# Altium schematic sheet MotorMCU.SchDoc
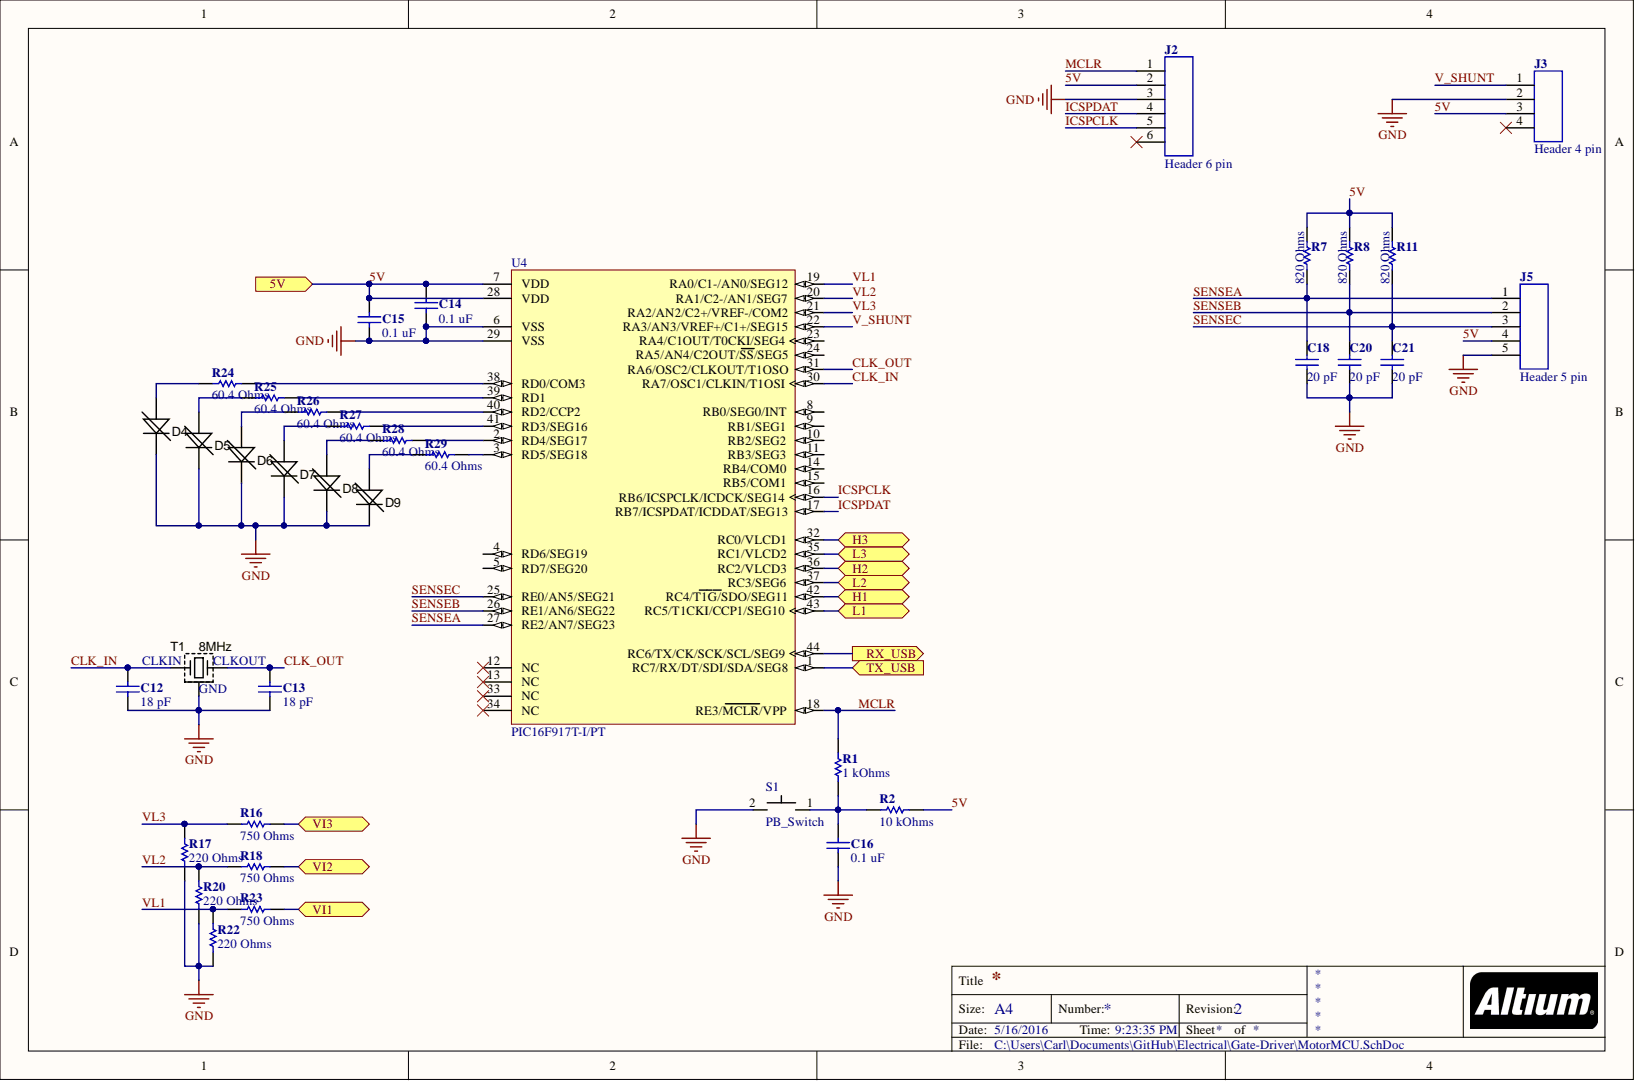
[diagram p0: MotorMCU.SchDoc]

## Parts
C12  18 pF  [CAP-0603(1608)-R]
C13  18 pF  [CAP-0603(1608)-R]
C14  0.1 uF  [CAP-0402(1005)-R]
C15  0.1 uF  [CAP-0402(1005)-R]
C16  0.1 uF  [CAP-0402(1005)-R]
C18  20 pF  [CAP-0402(1005)-R]
C20  20 pF  [CAP-0402(1005)-R]
C21  20 pF  [CAP-0402(1005)-R]
D4  Red  [LED-1206]  (value not drawn)
D5  Red  [LED-1206]  (value not drawn)
D6  Red  [LED-1206]  (value not drawn)
D7  Red  [LED-1206]  (value not drawn)
D8  Red  [LED-1206]  (value not drawn)
D9  Red  [LED-1206]  (value not drawn)
J2  Header 6 pin  [HEADER-6-100mil]
J3  Header 4 pin  [HEADER-4-100mil]
J5  Header 5 pin  [HEADER-5-100mil]
R1  1 kOhms  [R-0402(1005)-R]
R2  10 kOhms  [R-0603(1608)-R]
R7  820 Ohms  [R-0603(1608)-R]
R8  820 Ohms  [R-0603(1608)-R]
R11  820 Ohms  [R-0603(1608)-R]
R16  750 Ohms  [R-0603(1608)-R]
R17  220 Ohms  [R-0603(1608)-R]
R18  750 Ohms  [R-0603(1608)-R]
R20  220 Ohms  [R-0603(1608)-R]
R22  220 Ohms  [R-0603(1608)-R]
R23  750 Ohms  [R-0603(1608)-R]
R24  60.4 Ohms  [R-0603(1608)-R]
R25  60.4 Ohms  [R-0603(1608)-R]
R26  60.4 Ohms  [R-0603(1608)-R]
R27  60.4 Ohms  [R-0603(1608)-R]
R28  60.4 Ohms  [R-0603(1608)-R]
R29  60.4 Ohms  [R-0603(1608)-R]
S1  PB_Switch  [Pushbutton_switches]
T1  8MHz  Load Capacitance: 18 pF  [CRYSTAL-8MHZ]
U4  PIC16F917T-I/PT  [TQFP-44]

## Ports
5V, H1, H2, H3, L1, L2, L3, RX_USB, TX_USB, VI1, VI2, VI3

## Nets
GND: C12.2 C13.2 C14.2 C15.2 C16.1 C18.2 C20.2 C21.2 D4.K D5.K D6.K D7.K D8.K D9.K J2.3 J3.2 J5.5 R17.2 R20.2 R22.2 S1.2 T1.2 U4.6(VSS) U4.29(VSS)
5V: C14.1 C15.1 J2.2 J3.3 J5.4 R2.2 R7.1 R8.1 R11.1 U4.7(VDD) U4.28(VDD) ; port 5V
CLK_IN: C12.1 T1.1 U4.30(RA7/OSC1/CLKIN/T1OSI)
CLK_OUT: C13.1 T1.3 U4.31(RA6/OSC2/CLKOUT/T1OSO)
H1: U4.42(RC4/T1G/SDO/SEG11) ; port H1
H2: U4.36(RC2/VLCD3) ; port H2
H3: U4.32(RC0/VLCD1) ; port H3
ICSPCLK: J2.5 U4.16(RB6/ICSPCLK/ICDCK/SEG14)
ICSPDAT: J2.4 U4.17(RB7/ICSPDAT/ICDDAT/SEG13)
L1: U4.43(RC5/T1CKI/CCP1/SEG10) ; port L1
L2: U4.37(RC3/SEG6) ; port L2
L3: U4.35(RC1/VLCD2) ; port L3
MCLR: J2.1 R1.1 U4.18(RE3/MCLR/VPP)
RX_USB: U4.44(RC6/TX/CK/SCK/SCL/SEG9) ; port RX_USB
SENSEA: C18.1 J5.1 R7.2 U4.27(RE2/AN7/SEG23)
SENSEB: C20.1 J5.2 R8.2 U4.26(RE1/AN6/SEG22)
SENSEC: C21.1 J5.3 R11.2 U4.25(RE0/AN5/SEG21)
TX_USB: U4.1(RC7/RX/DT/SDI/SDA/SEG8) ; port TX_USB
V_SHUNT: J3.1 U4.22(RA3/AN3/VREF+/C1+/SEG15)
VI1: R23.2 ; port VI1
VI2: R18.2 ; port VI2
VI3: R16.2 ; port VI3
VL1: R22.1 R23.1 U4.19(RA0/C1-/AN0/SEG12)
VL2: R18.1 R20.1 U4.20(RA1/C2-/AN1/SEG7)
VL3: R16.1 R17.1 U4.21(RA2/AN2/C2+/VREF-/COM2)
NetC16_2: C16.2 R1.2 R2.1 S1.1
NetD4_A: D4.A R24.1
NetD5_A: D5.A R25.1
NetD6_A: D6.A R26.1
NetD7_A: D7.A R27.1
NetD8_A: D8.A R28.1
NetD9_A: D9.A R29.1
NetR24_2: R24.2 U4.38(RD0/COM3)
NetR25_2: R25.2 U4.39(RD1)
NetR26_2: R26.2 U4.40(RD2/CCP2)
NetR27_2: R27.2 U4.41(RD3/SEG16)
NetR28_2: R28.2 U4.2(RD4/SEG17)
NetR29_2: R29.2 U4.3(RD5/SEG18)

Not connected: J2 6; J3 4; U4 4(RD6/SEG19) 5(RD7/SEG20) 8(RB0/SEG0/INT) 9(RB1/SEG1) 10(RB2/SEG2) 11(RB3/SEG3) 12(NC) 13(NC) 14(RB4/COM0) 15(RB5/COM1) 23(RA4/C1OUT/T0CKI/SEG4) 24(RA5/AN4/C2OUT/SS/SEG5) 33(NC) 34(NC)

## Library data of the parts
C12 C13 = CAP- 18 pF- 25 V-CER-0603(1608): CAP CER 18 pF 10V 5% C0G 0603; Manufacturer Part Number: CC0603FRNPO9BN180; Brand: Yageo; Dielectric: C0G (NP0); Tolerance: 1 %; Type: Multilayer Ceramic Chip Capacitor; Voltage Rating DC: 50 VDC; Capacitance: 18 pF; Case Code - in: 0603; Case Code - mm: 1608; Category: Multilayer Ceramic Capacitors MLCC - SMD/SMT; Component Kind: Standard; ComponentLink1Description: http://www.mouser.com/ds/2/447/UPY-GP_NP0_16V-to-50V_8-3714.pdf; ComponentLink1URL: http://www.mouser.com/ds/2/447/UPY-GP_NP0_16V-to-50V_8-3714.pdf; Length: 1.6 mm; Manufacturer: Yageo; Maximum Operating Temperature: + 125 C; Minimum Operating Temperature: - 55 C; Minimum Order Quantity: 1; Package / Case: 0603 (1608 metric); Packaging: Reel; Pricing 1: 1=0.100, 10=0.039, 100=0.027, 1000=0.019, 4000=0.018, 8000=0.017, 24000=0.016, 48000=0.013 (USD); Product: General Type MLCCs; Reeling: True; RoHS: RoHS Compliant By Exemption; RoHS - Mouser: E; Stock 1: 2511; Supplier 1: Mouser; Supplier Part Number 1: 603-CC603FRNPO9BN180; Termination Style: SMD/SMT; Width: 0.8 mm; Library Name: CarlLibrary.SchLib
C14-C16 = CAP- 0.1 uF- 16 V-CER-0402(1005): CAP CER 0.1 UF 16V 5% X7R 0402; Manufacturer Part Number: CC0402KRX7R7BB104; Brand: Yageo; Tolerance: 10 %; Type: Multilayer Ceramic Chip Capacitor; Voltage Rating DC: 16 VDC; Capacitance: 0.1 uF; Case Code - in: 0402; Case Code - mm: 1005; Category: Multilayer Ceramic Capacitors MLCC - SMD/SMT; Component Kind: Standard; ComponentLink1Description: http://www.mouser.com/ds/2/447/UPY-GPHC_X7R_6.3V-to-50V_8-12304.pdf; ComponentLink1URL: http://www.mouser.com/ds/2/447/UPY-GPHC_X7R_6.3V-to-50V_8-12304.pdf; Dielectric: X7R; Manufacturer: Yageo; Maximum Operating Temperature: + 125 C; Minimum Operating Temperature: - 55 C; Minimum Order Quantity: 1; Package / Case: 0402 (1005 metric); Packaging: Reel; Pricing 1: 1=0.100, 10=0.009, 100=0.004, 1000=0.003, 2500=0.002, 10000=0.002 (USD); Product: General Type MLCCs; Reeling: True; RoHS: RoHS Compliant By Exemption; RoHS - Mouser: E; Standard Pack Qty: 10000; Stock 1: 655262; Supplier 1: Mouser; Supplier Part Number 1: 603-CC402KRX7R7BB104; Termination Style: SMD/SMT; Library Name: CarlLibrary.SchLib
C18 C20 C21 = CAP- 20 pF- 50 V-CER-0402(1005): CAP CER 20 pF 50V 5% C0G 0402; Manufacturer Part Number: CC0402JRNPO9BN200; Brand: Yageo; Dielectric: C0G (NP0); Type: Multilayer Ceramic Chip Capacitor; Voltage Rating DC: 50 VDC; Capacitance: 20 pF; Case Code - in: 0402; Case Code - mm: 1005; Category: Multilayer Ceramic Capacitors MLCC - SMD/SMT; Component Kind: Standard; ComponentLink1Description: http://www.mouser.com/ds/2/447/UPY-NP0X7R_MV_100-to-630V_15-594030.pdf; ComponentLink1URL: http://www.mouser.com/ds/2/447/UPY-NP0X7R_MV_100-to-630V_15-594030.pdf; Length: 1 mm; Manufacturer: Yageo; Maximum Operating Temperature: + 125 C; Minimum Operating Temperature: - 55 C; Minimum Order Quantity: 1; Package / Case: 0402 (1005 metric); Packaging: Reel; Pricing 1: 1=0.100, 10=0.010, 100=0.005, 1000=0.004, 2500=0.003, 10000=0.002 (USD); Product: General Type MLCCs; Reeling: True; RoHS: RoHS Compliant By Exemption; RoHS - Mouser: E; Standard Pack Qty: 10000; Stock 1: 75922; Supplier 1: Mouser; Supplier Part Number 1: 603-CC402JRNPO9BN200; Termination Style: SMD/SMT; Tolerance: 5 %; Width: 0.5 mm; Library Name: CarlLibrary.SchLib
D4-D9 = LED_SMD_RED_0805: LED, 0805 SMD, Red; Manufacturer Part Number: APTD3216SURCK; Brand: Kingbright; If - Forward Current: 20 mA; LED Size: 3.2 mm x 1.6 mm; Lens Color/Style: Water Clear; Luminous Intensity: 1000 mcd; Vf - Forward Voltage: 1.95 V; Viewing Angle: 50 deg; Wavelength/Color Temperature: 630 nm; Category: Standard LEDs - SMD; Component Kind: Standard; ComponentLink1Description: http://www.mouser.com/ds/2/216/APTD3216SURCK-65552.pdf; ComponentLink1URL: http://www.mouser.com/ds/2/216/APTD3216SURCK-65552.pdf; Illumination Color: Red; Manufacturer: Kingbright; Maximum Operating Temperature: + 85 C; Minimum Operating Temperature: - 40 C; Minimum Order Quantity: 1; Mounting Style: SMD/SMT; Package / Case: 1206; Packaging: Reel; Pricing 1: 1=0.270, 10=0.169, 100=0.125, 1000=0.103, 2000=0.092, 10000=0.084, 24000=0.075, 50000=0.071, 100000=0.068 (USD); Product Category: LED SMD Super Bright; Reeling: True; RoHS: RoHS Compliant; RoHS - Mouser: Y; Standard Pack Qty: 2000; Stock 1: 52291; Supplier 1: Mouser; Supplier Part Number 1: 604-APTD-3216SURCK; Library Name: CarlLibrary.SchLib
J2 = CON-6Pin-100Mil: Header, 6 pin, .1 pitch; Manufacturer Part Number: 4-103327-6; Brand: TE Connectivity / AMP; Current Rating: 3 A; Insulation Resistance: 5000 MOhms; Mating Post Length: 5.84 mm; Mounting Angle: Vertical; Number of Positions: 6 Position; Pitch: 2.54 mm; Category: Headers & Wire Housings; Component Kind: Standard; ComponentLink1Description: http://www.mouser.com/ds/2/418/NG_CD_103327_T_baseFilename-749374.pdf; ComponentLink1URL: http://www.mouser.com/ds/2/418/NG_CD_103327_T_baseFilename-749374.pdf; Contact Gender: Pin (Male); Contact Material: Phosphor Bronze; Contact Plating: Tin; Flammability Rating: UL 94 V-0; Housing Material: Thermoplastic; Manufacturer: TE Connectivity / AMP; Minimum Order Quantity: 1; Mounting Style: -; Number of Rows: 1 Row; Operating Temperature Range: - 65 C to + 105 C; Pricing 1: 1=0.230, 25=0.226, 50=0.212, 100=0.198 (USD); Product: Headers; Reeling: False; Row Spacing: 2.54 mm; Series: AMPMODU MOD II; Standard Pack Qty: 240; Stock 1: 9402; Supplier 1: Mouser; Supplier Part Number 1: 571-4-103327-6; Termination Post Length: 3.05 mm; Termination Style: Through Hole; Tradename: AMPMODU; Type: Breakaway; Library Name: CarlLibrary.SchLib
J3 = CON-4Pin-100Mil: Header, 4 pin, .1 pitch; Manufacturer Part Number: 90136-1104; Brand: Molex; Current Rating: 3 A; Mounting Angle: Vertical; Number of Positions: 4 Position; Pitch: 2.54 mm; Qualification: Commercial; Voltage Rating: 350 VAC/DC; Accessory Type: -; Category: Headers & Wire Housings; Component Kind: Standard; ComponentLink1Description: http://www.mouser.com/ds/2/276/0901361104_PCB_HEADERS-176953.pdf; ComponentLink1URL: http://www.mouser.com/ds/2/276/0901361104_PCB_HEADERS-176953.pdf; Contact Gender: Pin (Male); Contact Material: Brass; Contact Plating: Tin; Contact Type: -; Housing Material: Polyester; Manufacturer: Molex; Minimum Order Quantity: 1; Mounting Style: Straight Pin; Number of Rows: 1 Row; Packaging: Tray; Pricing 1: 1=1.17, 10=0.906, 100=0.717, 500=0.646, 1000=0.574, 2000=0.502 (USD); Product: Headers; Product Category: C-Grid Connectors; Reeling: False; RoHS: RoHS Compliant; RoHS - Mouser: Y; Row Spacing: -; Series: 90136; Standard Pack Qty: 1920; Stock 1: 2253; Supplier 1: Mouser; Supplier Part Number 1: 538-90136-1104; Termination Style: Through Hole; Tradename: C-Grid; Type: Shrouded; Library Name: CarlLibrary.SchLib
J5 = CON-5Pin-100Mil: Header, 5 pin, .1 pitch; Manufacturer Part Number: 90136-1105; Brand: Molex; Current Rating: 3 A; Mounting Angle: Vertical; Number of Positions: 5 Position; Pitch: 2.54 mm; Qualification: Commercial; Voltage Rating: 350 VAC/DC; Accessory Type: -; Category: Headers & Wire Housings; Component Kind: Standard; ComponentLink1Description: http://www.mouser.com/ds/2/276/0901361105_PCB_HEADERS-151780.pdf; ComponentLink1URL: http://www.mouser.com/ds/2/276/0901361105_PCB_HEADERS-151780.pdf; Contact Gender: Pin (Male); Contact Material: Brass; Contact Plating: Tin; Contact Type: -; Housing Material: Polyester; Manufacturer: Molex; Minimum Order Quantity: 1; Mounting Style: Through Hole; Number of Rows: 1 Row; Packaging: Tray; Pricing 1: 1=1.17, 10=0.934, 100=0.749, 500=0.675, 1000=0.600, 2000=0.544, 5000=0.525, 10000=0.461 (USD); Product: Headers; Product Category: C-Grid Connectors; Reeling: False; RoHS: RoHS Compliant; RoHS - Mouser: Y; Row Spacing: -; Series: 90136; Standard Pack Qty: 1600; Stock 1: 2202; Supplier 1: Mouser; Supplier Part Number 1: 538-90136-1105; Termination Style: Through Hole; Tradename: C-Grid; Type: Shrouded; Library Name: CarlLibrary.SchLib
R1 = R-1K-62.5 mW-0402(1005): RES 1K OHM 62.5 mW 0402(1005) SMD; Manufacturer Part Number: RC0402JR-071KL; Brand: Yageo; Power Rating: 62.5 mW (1/16 W); Temperature Coefficient: 100 PPM / C; Tolerance: 5 %; Voltage Rating: 50 V; Case Code - in: 0402; Case Code - mm: 1005; Category: Thick Film Resistors - SMD; Component Kind: Standard; ComponentLink1Description: http://www.mouser.com/ds/2/447/PYu-RC0402_51_RoHS_L_6_r-8721.pdf; ComponentLink1URL: http://www.mouser.com/ds/2/447/PYu-RC0402_51_RoHS_L_6_r-8721.pdf; Height: 0.35 mm; Length: 1 mm; Manufacturer: Yageo; Minimum Order Quantity: 1; Operating Temperature Range: - 55 C to + 155 C; Package / Case: 0402 (1005 metric); Packaging: Reel; Pricing 1: 1=0.100, 10=0.007, 100=0.002, 2500=0.001, 10000=0.001 (USD); Product: Thick Film Resistors General Purpose; Product Category: 0402 SMD Chip Resistors; Reeling: True; Resistance: 1 kOhms; RoHS: RoHS Compliant; RoHS - Mouser: Y; Series: RC; Standard Pack Qty: 10000; Stock 1: 20226; Supplier 1: Mouser; Supplier Part Number 1: 603-RC0402JR-071KL; Termination Style: SMD/SMT; Type: General Purpose Chip Resistor Surface Mount; Width: 0.5 mm; Library Name: CarlLibrary.SchLib
R2 = R-10k-100mW-0603: RES 10k OHM 1/10W 0603 SMD; Manufacturer Part Number: RC0603FR-0710KL; Brand: Yageo; Power Rating: 100 mW; Temperature Coefficient: 100 PPM / C; Tolerance: 1 %; Voltage Rating: 75 V; Case Code - in: 0603; Case Code - mm: 1608; Category: Thick Film Resistors - SMD; Component Kind: Standard; ComponentLink1Description: http://www.mouser.com/ds/2/447/RC0603-272842.pdf; ComponentLink1URL: http://www.mouser.com/ds/2/447/RC0603-272842.pdf; Height: 0.45 mm; Length: 1.6 mm; Manufacturer: Yageo; Minimum Order Quantity: 1; Operating Temperature Range: - 55 C to + 155 C; Package / Case: 0603 (1608 metric); Packaging: Reel; Pricing 1: 1=0.100, 10=0.010, 100=0.003, 1000=0.002, 5000=0.002, 10000=0.001 (USD); Product: Thick Film Resistors General Purpose; Product Category: 0603 SMD Chip Resistors; Reeling: True; Resistance: 10 kOhms; RoHS: RoHS Compliant; RoHS - Mouser: Y; Series: RC; Standard Pack Qty: 5000; Stock 1: 240719; Supplier 1: Mouser; Supplier Part Number 1: 603-RC0603FR-0710KL; Termination Style: SMD/SMT; Type: General Purpose Chip Resistor Surface Mount; Width: 0.8 mm; Library Name: CarlLibrary.SchLib
R7 R8 R11 = R-820-100mW-0603(1608): RES 820 OHM 100 mW 0603(1608) SMD; Manufacturer Part Number: RT0603DRD07820RL; Brand: Yageo; Power Rating: 100 mW; Temperature Coefficient: 25 PPM / C; Tolerance: 0.5 %; Voltage Rating: 75 V; Case Code - in: 0603; Case Code - mm: 1608; Category: Thin Film Resistors - SMD; Component Kind: Standard; ComponentLink1Description: http://www.mouser.com/ds/2/447/PYu-RT_1-to-0.05_RoHS_L_6-772266.pdf; ComponentLink1URL: http://www.mouser.com/ds/2/447/PYu-RT_1-to-0.05_RoHS_L_6-772266.pdf; Height: 0.45 mm; Length: 1.6 mm; Manufacturer: Yageo; Minimum Order Quantity: 1; Operating Temperature Range: - 55 C to + 125 C; Package / Case: 0603 (1608 metric); Packaging: Reel; Pricing 1: 1=0.140, 10=0.077, 100=0.027, 1000=0.019, 5000=0.013, 10000=0.012, 25000=0.011, 50000=0.010 (USD); Product: Thin Film Resistors SMD; Reeling: True; Resistance: 820 Ohms; RoHS: RoHS Compliant; RoHS - Mouser: Y; Series: RT; Stock 1: 5000; Supplier 1: Mouser; Supplier Part Number 1: 603-RT0603DRD07820RL; Termination Style: SMD/SMT; Type: High Precision, High Stability; Width: 0.8 mm; Library Name: CarlLibrary.SchLib
R16 R18 R23 = R-750-100mW-0603(1608): RES 750 OHM 100 mW 0603(1608) SMD; Manufacturer Part Number: RT0603DRD07750RL; Brand: Yageo; Power Rating: 100 mW; Temperature Coefficient: 25 PPM / C; Tolerance: 0.5 %; Voltage Rating: 75 V; Case Code - in: 0603; Case Code - mm: 1608; Category: Thin Film Resistors - SMD; Component Kind: Standard; ComponentLink1Description: http://www.mouser.com/ds/2/447/PYu-RT_1-to-0.05_RoHS_L_6-772266.pdf; ComponentLink1URL: http://www.mouser.com/ds/2/447/PYu-RT_1-to-0.05_RoHS_L_6-772266.pdf; Height: 0.45 mm; Length: 1.6 mm; Manufacturer: Yageo; Minimum Order Quantity: 1; Operating Temperature Range: - 55 C to + 125 C; Package / Case: 0603 (1608 metric); Packaging: Reel; Pricing 1: 1=0.140, 10=0.077, 100=0.027, 1000=0.019, 5000=0.013, 10000=0.012, 25000=0.011, 50000=0.010 (USD); Product: Thin Film Resistors SMD; Reeling: True; Resistance: 750 Ohms; RoHS: RoHS Compliant; RoHS - Mouser: Y; Series: RT; Stock 1: 4747; Supplier 1: Mouser; Supplier Part Number 1: 603-RT0603DRD07750RL; Termination Style: SMD/SMT; Type: High Precision, High Stability; Width: 0.8 mm; Library Name: CarlLibrary.SchLib
R17 R20 R22 = R-220-100 mW-0603(1608): RES 220 OHM 100 mW 0603(1608) SMD; Manufacturer Part Number: RT0603FRE07220RL; Brand: Yageo; Power Rating: 100 mW; Temperature Coefficient: 50 PPM / C; Tolerance: 1 %; Voltage Rating: 75 V; Case Code - in: 0603; Case Code - mm: 1608; Category: Thin Film Resistors - SMD; Component Kind: Standard; ComponentLink1Description: http://www.mouser.com/ds/2/447/PYu-RT_1-to-0.05_RoHS_L_6-772266.pdf; ComponentLink1URL: http://www.mouser.com/ds/2/447/PYu-RT_1-to-0.05_RoHS_L_6-772266.pdf; Height: 0.45 mm; Length: 1.6 mm; Manufacturer: Yageo; Minimum Order Quantity: 1; Operating Temperature Range: - 55 C to + 125 C; Package / Case: 0603 (1608 metric); Packaging: Reel; Pricing 1: 1=0.190, 10=0.046, 100=0.015, 1000=0.011, 5000=0.007, 25000=0.006, 50000=0.005 (USD); Product: Thin Film Resistors SMD; Reeling: True; Resistance: 220 Ohms; RoHS: RoHS Compliant By Exemption; RoHS - Mouser: E; Series: RT; Stock 1: 6189; Supplier 1: Mouser; Supplier Part Number 1: 603-RT0603FRE07220RL; Termination Style: SMD/SMT; Type: High Precision, High Stability; Width: 0.8 mm; Library Name: CarlLibrary.SchLib
R24-R29 = R-60.4-100mW-0805: RES 60.4 OHM 100 mW  SMD; Manufacturer Part Number: RC0603FR-0760R4L; Brand: Yageo; Power Rating: 100 mW; Temperature Coefficient: 100 PPM / C; Tolerance: 1 %; Voltage Rating: 75 V; Case Code - in: 0603; Case Code - mm: 1608; Category: Thick Film Resistors - SMD; Component Kind: Standard; ComponentLink1Description: http://www.mouser.com/ds/2/447/RC0603-272842.pdf; ComponentLink1URL: http://www.mouser.com/ds/2/447/RC0603-272842.pdf; Height: 0.45 mm; Length: 1.6 mm; Manufacturer: Yageo; Minimum Order Quantity: 1; Operating Temperature Range: - 55 C to + 155 C; Package / Case: 0603 (1608 metric); Packaging: Reel; Pricing 1: 1=0.100, 10=0.010, 100=0.003, 1000=0.002, 5000=0.002, 10000=0.001 (USD); Product: Thick Film Resistors General Purpose; Reeling: True; Resistance: 60.4 Ohms; RoHS: RoHS Compliant; RoHS - Mouser: Y; Series: RC; Stock 1: 5823; Supplier 1: Mouser; Supplier Part Number 1: 603-RC0603FR-0760R4L; Termination Style: SMD/SMT; Type: General Purpose Chip Resistor Surface Mount; Width: 0.8 mm; Library Name: CarlLibrary.SchLib
S1 = PB_Switch: Manufacturer Part Number: 2-1437565-8; Actuator: Round; Brand: TE Connectivity / Alcoswitch; Contact Form: SPST; Current Rating: 50 mA; Illumination: None; Switch Function: OFF - (ON); Voltage Rating DC: 24 VDC; Category: Pushbutton Switches; Component Kind: Standard; ComponentLink1Description: http://www.mouser.com/ds/2/418/NG_CD_2-1437565-7_V-663783.pdf; ComponentLink1URL: http://www.mouser.com/ds/2/418/NG_CD_2-1437565-7_V-663783.pdf; Contact Plating: Silver; Height: 4.3 mm; Library Name: MasterLibrary.SchLib; Manufacturer: TE Connectivity / Alcoswitch; Material: Polyamide; Minimum Order Quantity: 1; Mounting Style: SMD/SMT; Pricing 1: 1=0.140, 10=0.130, 25=0.117, 50=0.108, 100=0.097, 200=0.092, 500=0.087, 1000=0.083 (USD); Product Category: Pushbutton Switches; Reeling: False; Standard Pack Qty: 750; Stock 1: 18161; Supplier 1: Mouser; Supplier Part Number 1: 506-2-1437565-8; Termination Style: Solder Pin
T1 = CRYSTAL_8MHz: Oscillator Crystal 8MHz 10ppm; Manufacturer Part Number: ABMM2-8.000MHZ-E2-T; Brand: ABRACON; ESR: 72 Ohms; Frequency Stability: 20 PPM; Type: Ceramic Low Profile Quartz Crystal; Category: Crystals; Component Kind: Standard; ComponentLink1Description: http://www.mouser.com/ds/2/3/ABMM2-40161.pdf; ComponentLink1URL: http://www.mouser.com/ds/2/3/ABMM2-40161.pdf; Frequency: 8 MHz; Height: 1.2 mm; Length: 6 mm; Manufacturer: ABRACON; Minimum Order Quantity: 1; Mounting Style: SMD/SMT; Operating Temperature Range: - 20 C to + 70 C; Package / Case: 6 mm x 3.6 mm; Packaging: Reel; Pricing 1: 1=0.930, 10=0.823, 50=0.720, 100=0.650, 200=0.630, 500=0.520, 1000=0.470, 2000=0.460, 5000=0.442 (USD); Product Category: SMD Crystals; Reeling: True; RoHS: RoHS Compliant; RoHS - Mouser: Y; Series: ABMM2; Standard Pack Qty: 1000; Stock 1: 13032; Supplier 1: Mouser; Supplier Part Number 1: 815-ABMM2-8-E2T; Termination Style: SMD/SMT; Tolerance: 20 PPM; Width: 3.6 mm; Library Name: CarlLibrary.SchLib
U4 = PIC16F917: Flash-Based, 8-Bit CMOS Microcontroller with LCD Driver and nanoWatt Technology, 8K (x14-Bit words) Program Memory, 608 Bytes Data Memory, 35 I/O pins, 44-Pin TQFP, Standard VDD Range, Industrial Temperature, Tape and Reel; Manufacturer Part Number: PIC16F917-I/PT; ADC Resolution: 10 bit; Brand: Microchip Technology; Core: PIC16; Data Bus Width: 8 bit; Data RAM Size: 352 B; Data RAM Type: RAM; Data ROM Size: 256 B; Data ROM Type: EEPROM; Interface Type: AUSART, I2C, SPI, SSP; Maximum Clock Frequency: 20 MHz; Number of ADC Channels: 8; Number of I/Os: 35 I/O; Number of Timers/Counters: 2 Timer; Operating Supply Voltage: 2 V to 5.5 V; PackagingOptionsScrubbed: PIC16F917TIPT; Program Memory Size: 14 kB; Program Memory Type: Flash; Supply Voltage - Max: 5.5 V; Supply Voltage - Min: 4.5 V; Category: 8-bit Microcontrollers - MCU; Component Kind: Standard; ComponentLink1Description: http://www.mouser.com/ds/2/268/41250B-80422.pdf; ComponentLink1URL: http://www.mouser.com/ds/2/268/41250B-80422.pdf; Library Name: MasterLibrary.SchLib; Manufacturer: Microchip Technology; Maximum Operating Temperature: + 85 C; Minimum Operating Temperature: - 40 C; Minimum Order Quantity: 1; Mounting Style: SMD/SMT; Package / Case: TQFP-44; Packaging: Tray; Pricing 1: 1=3.59, 10=2.99, 25=2.74, 100=2.48 (USD); Processor Series: PIC16; Product: MCU; Product Category: PICmicro MCUs; Reeling: False; RoHS: RoHS Compliant; RoHS - Mouser: Y; Series: PIC16F917; Standard Pack Qty: 160; Stock 1: 160; Supplier 1: Mouser; Supplier Part Number 1: 579-PIC16F917-I/PT
